# Altium schematic sheet MCU.SchDoc
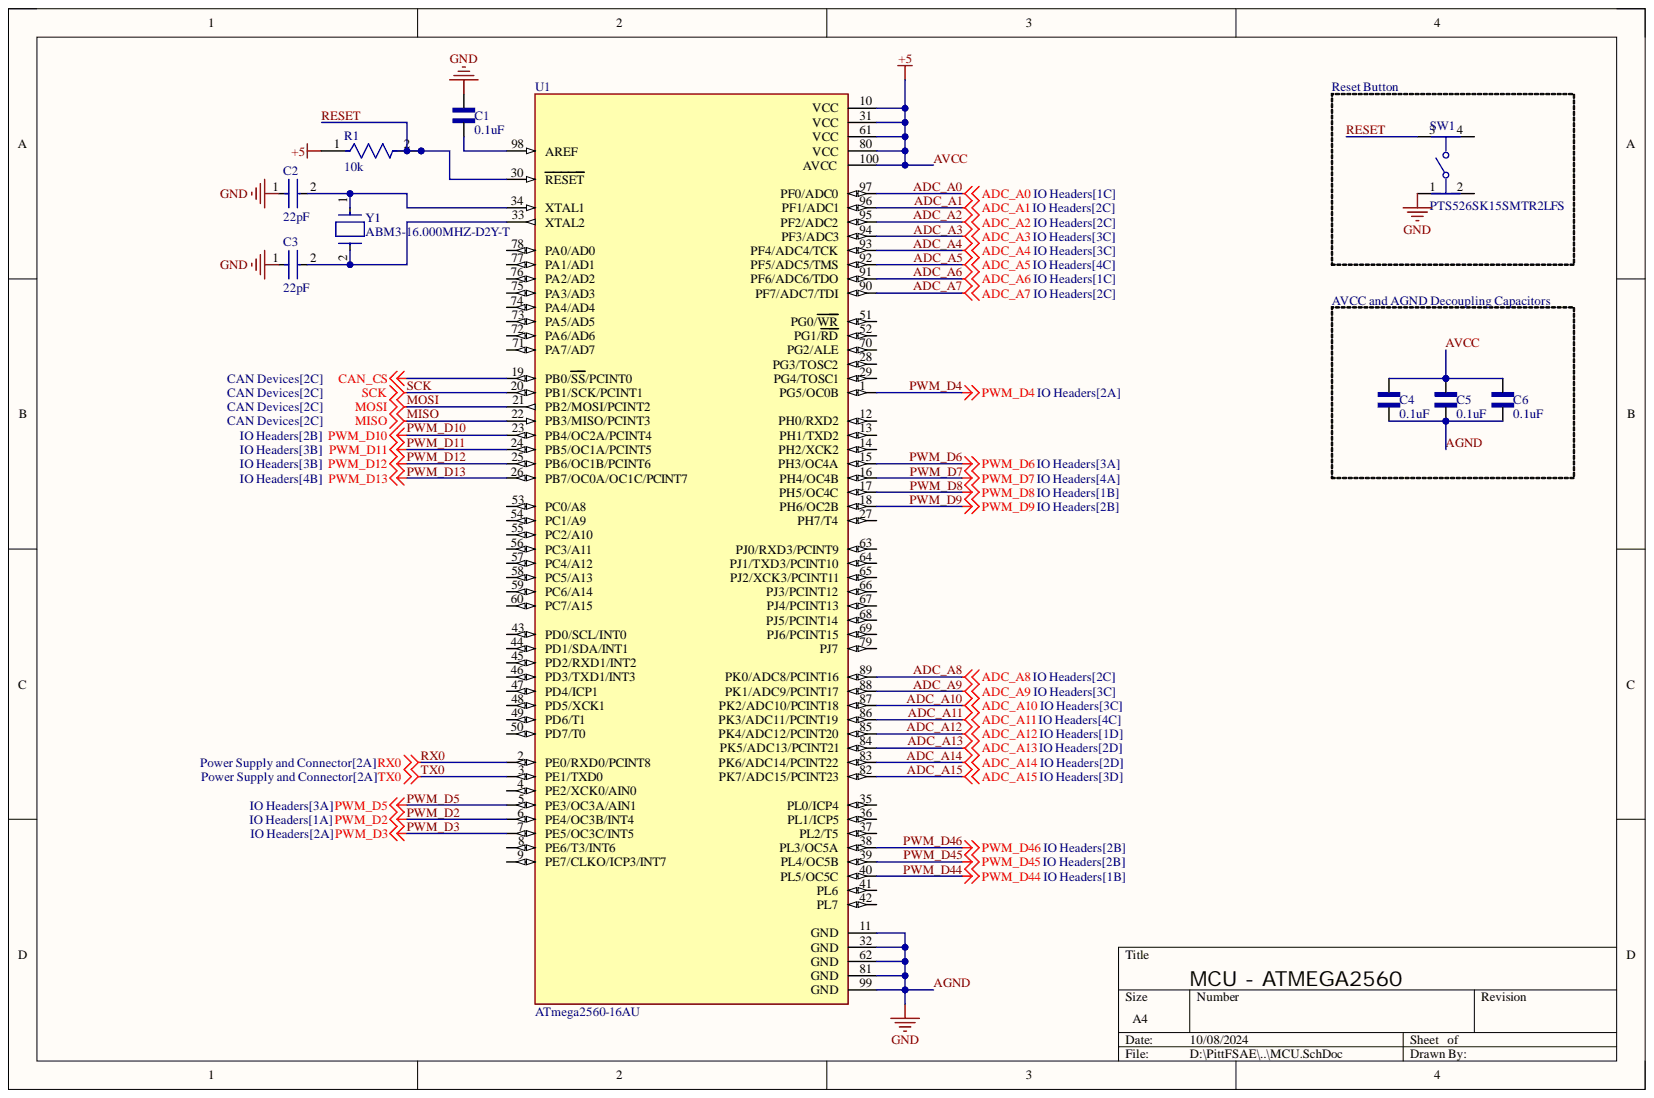
[diagram p0: MCU.SchDoc]

## Parts
C1  0.1uF  [CAPC2012X135N]
C2  22pF  [FP-C0805C-DN-MFG]
C3  22pF  [FP-C0805C-DN-MFG]
C4  0.1uF  [CAPC2012X135N]
C5  0.1uF  [CAPC2012X135N]
C6  0.1uF  [CAPC2012X135N]
R1  10k  [FP-CRCW0805-e3-IPC_A]
SW1  PTS526SK15SMTR2LFS  [FP-PTS526SK15SMTR2LFS-MFG]
U1  ATmega2560-16AU  [QFP50P1600X1600X120-100N]
Y1  ABM3-16.000MHZ-D2Y-T  [FP-ABM3-MFG]

## Nets
CAN_CS: U1.19(PB0/SS/PCINT0)
ADC_A0: U1.97(PF0/ADC0)
ADC_A1: U1.96(PF1/ADC1)
ADC_A2: U1.95(PF2/ADC2)
ADC_A3: U1.94(PF3/ADC3)
ADC_A4: U1.93(PF4/ADC4/TCK)
ADC_A5: U1.92(PF5/ADC5/TMS)
ADC_A6: U1.91(PF6/ADC6/TDO)
ADC_A7: U1.90(PF7/ADC7/TDI)
ADC_A8: U1.89(PK0/ADC8/PCINT16)
ADC_A9: U1.88(PK1/ADC9/PCINT17)
ADC_A10: U1.87(PK2/ADC10/PCINT18)
ADC_A11: U1.86(PK3/ADC11/PCINT19)
ADC_A12: U1.85(PK4/ADC12/PCINT20)
ADC_A13: U1.84(PK5/ADC13/PCINT21)
ADC_A14: U1.83(PK6/ADC14/PCINT22)
ADC_A15: U1.82(PK7/ADC15/PCINT23)
AGND: C1.1 C2.1 C3.1 C4.2 C5.2 C6.2 SW1.1 U1.11(GND) U1.32(GND) U1.62(GND) U1.81(GND) U1.99(GND)
AVCC: C4.1 C5.1 C6.1 R1.1 U1.10(VCC) U1.31(VCC) U1.61(VCC) U1.80(VCC) U1.100(AVCC)
MISO: U1.22(PB3/MISO/PCINT3)
MOSI: U1.21(PB2/MOSI/PCINT2)
PWM_D2: U1.6(PE4/OC3B/INT4)
PWM_D3: U1.7(PE5/OC3C/INT5)
PWM_D4: U1.1(PG5/OC0B)
PWM_D5: U1.5(PE3/OC3A/AIN1)
PWM_D6: U1.15(PH3/OC4A)
PWM_D7: U1.16(PH4/OC4B)
PWM_D8: U1.17(PH5/OC4C)
PWM_D9: U1.18(PH6/OC2B)
PWM_D10: U1.23(PB4/OC2A/PCINT4)
PWM_D11: U1.24(PB5/OC1A/PCINT5)
PWM_D12: U1.25(PB6/OC1B/PCINT6)
PWM_D13: U1.26(PB7/OC0A/OC1C/PCINT7)
PWM_D44: U1.40(PL5/OC5C)
PWM_D45: U1.39(PL4/OC5B)
PWM_D46: U1.38(PL3/OC5A)
RESET: R1.2 SW1.3 U1.30(RESET)
RX0: U1.2(PE0/RXD0/PCINT8)
SCK: U1.20(PB1/SCK/PCINT1)
TX0: U1.3(PE1/TXD0)
NetC1_2: C1.2 U1.98(AREF)
NetC2_2: C2.2 U1.34(XTAL1) Y1.1
NetC3_2: C3.2 U1.33(XTAL2) Y1.2

Not connected: SW1 2 4; U1 4(PE2/XCK0/AIN0) 8(PE6/T3/INT6) 9(PE7/CLKO/ICP3/INT7) 12(PH0/RXD2) 13(PH1/TXD2) 14(PH2/XCK2) 27(PH7/T4) 28(PG3/TOSC2) 29(PG4/TOSC1) 35(PL0/ICP4) 36(PL1/ICP5) 37(PL2/T5) 41(PL6) 42(PL7) 43(PD0/SCL/INT0) 44(PD1/SDA/INT1) 45(PD2/RXD1/INT2) 46(PD3/TXD1/INT3) 47(PD4/ICP1) 48(PD5/XCK1) 49(PD6/T1) 50(PD7/T0) 51(PG0/WR) 52(PG1/RD) 53(PC0/A8) 54(PC1/A9) 55(PC2/A10) 56(PC3/A11) 57(PC4/A12) 58(PC5/A13) 59(PC6/A14) 60(PC7/A15) 63(PJ0/RXD3/PCINT9) 64(PJ1/TXD3/PCINT10) 65(PJ2/XCK3/PCINT11) 66(PJ3/PCINT12) 67(PJ4/PCINT13) 68(PJ5/PCINT14) 69(PJ6/PCINT15) 70(PG2/ALE) 71(PA7/AD7) 72(PA6/AD6) 73(PA5/AD5) 74(PA4/AD4) 75(PA3/AD3) 76(PA2/AD2) 77(PA1/AD1) 78(PA0/AD0) 79(PJ7)

## Library data of the parts
C1 C4-C6 = GCM21BR71H104KA37L: CAP, MLCC, X7R, 0.1UF, 50V, 0805; MF: {'manufacturer': u'Murata'}; Availability: Unavailable; Component Kind: Standard; MP: GCM21BR71H104KA37L; Package: None; Price: None
C2 C3 = CMP-2007-00448-2: CAP CER 22PF 100V 5% NP0 0805; Manufacturer: KEMET; Manufacturer Part Number: C0805C220J1GACTU; Material: Ceramic; Temperature Characteristic: C0G; Temperature Coefficient: 30ppm/°C; Tolerance: 0.05; Automotive: No; Capacitance: 22 pF; Category: Cap; Component Kind: Standard; ComponentLink2Description: Datasheet; ComponentLink2URL: https://content.kemet.com/datasheets/KEM_C1003_C0G_SMD.pdf; Device Class L1: Passive Components; Device Class L2: Capacitors; Device Class L3: Ceramic Capacitors; Digikey Description: CAP CER 22PF 100V 5% NP0 0805; Height: 0.88mm; Ipc Land Pattern Name: CAPC200125X78; Lead Free: Yes; Library Name: Altium Content Vault; Mouser Description: Multilayer Ceramic Capacitor, C Series, 22 Pf, - 5%, C0g / Np0, 100 V, 0805 [2012 Metric] Rohs Compliant: Yes; Octopart: https://octopart.com/c0805c220j1gactu-kemet-3791395; Package: C0805C-DN; RoHS: TRUE; Temperature: -55°C to +125°C; Temperature Range High: +125°C; Temperature Range Low: -55°C; Value: 22 pF; Voltage Rating: 100 V
R1 = CMP-2001-04908-2: RES Thick Film, 10kΩ, 1%, 0.125W, 100ppm/°C, 0805; Power Rating: 125mW; Resistance: 10kR; Voltage Rating: 150V; Case Code (Imperial): 0805; Case Code (Metric): 2012; Case/Package: 0805; Component Kind: Standard; Composition: Thick Film; Contact Plating: Tin; Depth: 1.25mm; Height: 0.45mm; Lead Free: Lead Free; Length: 2mm; Library Name: Altium Content Vault; Max Operating Temperature: 155°C; Military Standard: Not; Min Operating Temperature: -55°C; Package Quantity: 5000; Packaging: Tape and Reel; Radiation Hardening: No; Temperature Coefficient: 100ppm/°C; Termination: SMD/SMT; Tolerance: 1%; Voltage Rating (DC): 150V; Weight: 0.000194oz; Width: 1.25mm
SW1 = CMP-10040-000013-1: TACT 5.2 X 5.2, 1.5 MM H, 260GF,; Manufacturer: C&K; Contact Current Rating: 50mA; Contact Resistance: 100mΩ; Electromechanical Life: 200000Cycles; Operating Force: 250gf; Standoff Height: 0mm; Throw Configuration: SPST-NO; Voltage Rating Dc: 12V; Automotive: No; Category: Switch; Component Kind: Standard; ComponentLink2Description: Datasheet; ComponentLink2URL: https://www.ckswitches.com/media/2780/pts526.pdf; Device Class L1: Electromechanical; Device Class L2: Switches; Device Class L3: Tactile Switches; Digikey Description: TACT 5.2 X 5.2, 1.5 MM H, 260GF,; Height: 1.65mm; Lead Free: Yes; Library Name: Altium Content Vault; Manufacturer Part Number: PTS526SK15SMTR2LFS; Octopart: https://octopart.com/pts526+sk15+smtr2+lfs-c%26k+components-100617999; Package: PTS526SK15SMTR2LFS; RoHS: TRUE; Temperature: -20°C to +70°C; Temperature Range High: +70°C; Temperature Range Low: -20°C
U1 = ATmega2560-16AU: MF: {'manufacturer': u'Microchip Technology'}; Availability: Unavailable; Component Kind: Standard; MP: ATmega2560-16AU; Package: None; Price: None
Y1 = CMP-27762-004490-1: Crystal 16MHz ±20ppm 18pF SMD-2 5mm x 3.2mm; ESR (Equivalent Series Resistance): 40R; Frequency Stability: 30ppm; Case/Package: SMD/SMT; Component Kind: Standard; Frequency: 16MHz; Frequency Tolerance: 20ppm; Height: 0.051inch; Lead Free: Lead Free; Length: 0.197inch; Library Name: Altium Content Vault; Load Capacitance: 18pF; Max Operating Temperature: 85°C; Min Operating Temperature: -40°C; Mount: Surface Mount; Number of Pins: 2; Operating Frequency: 16 MHz; Package Quantity: 1000; Packaging: Tape and Reel; Resistor Type: Ceramic; RoHS Compliant: Yes; Series: ABM3; Series Resistance: 40R; Termination: SMD/SMT; Tolerance: 20ppm; Width: 0.126inch
